# Altium schematic sheet Sheet4.SchDoc(Sheet4)
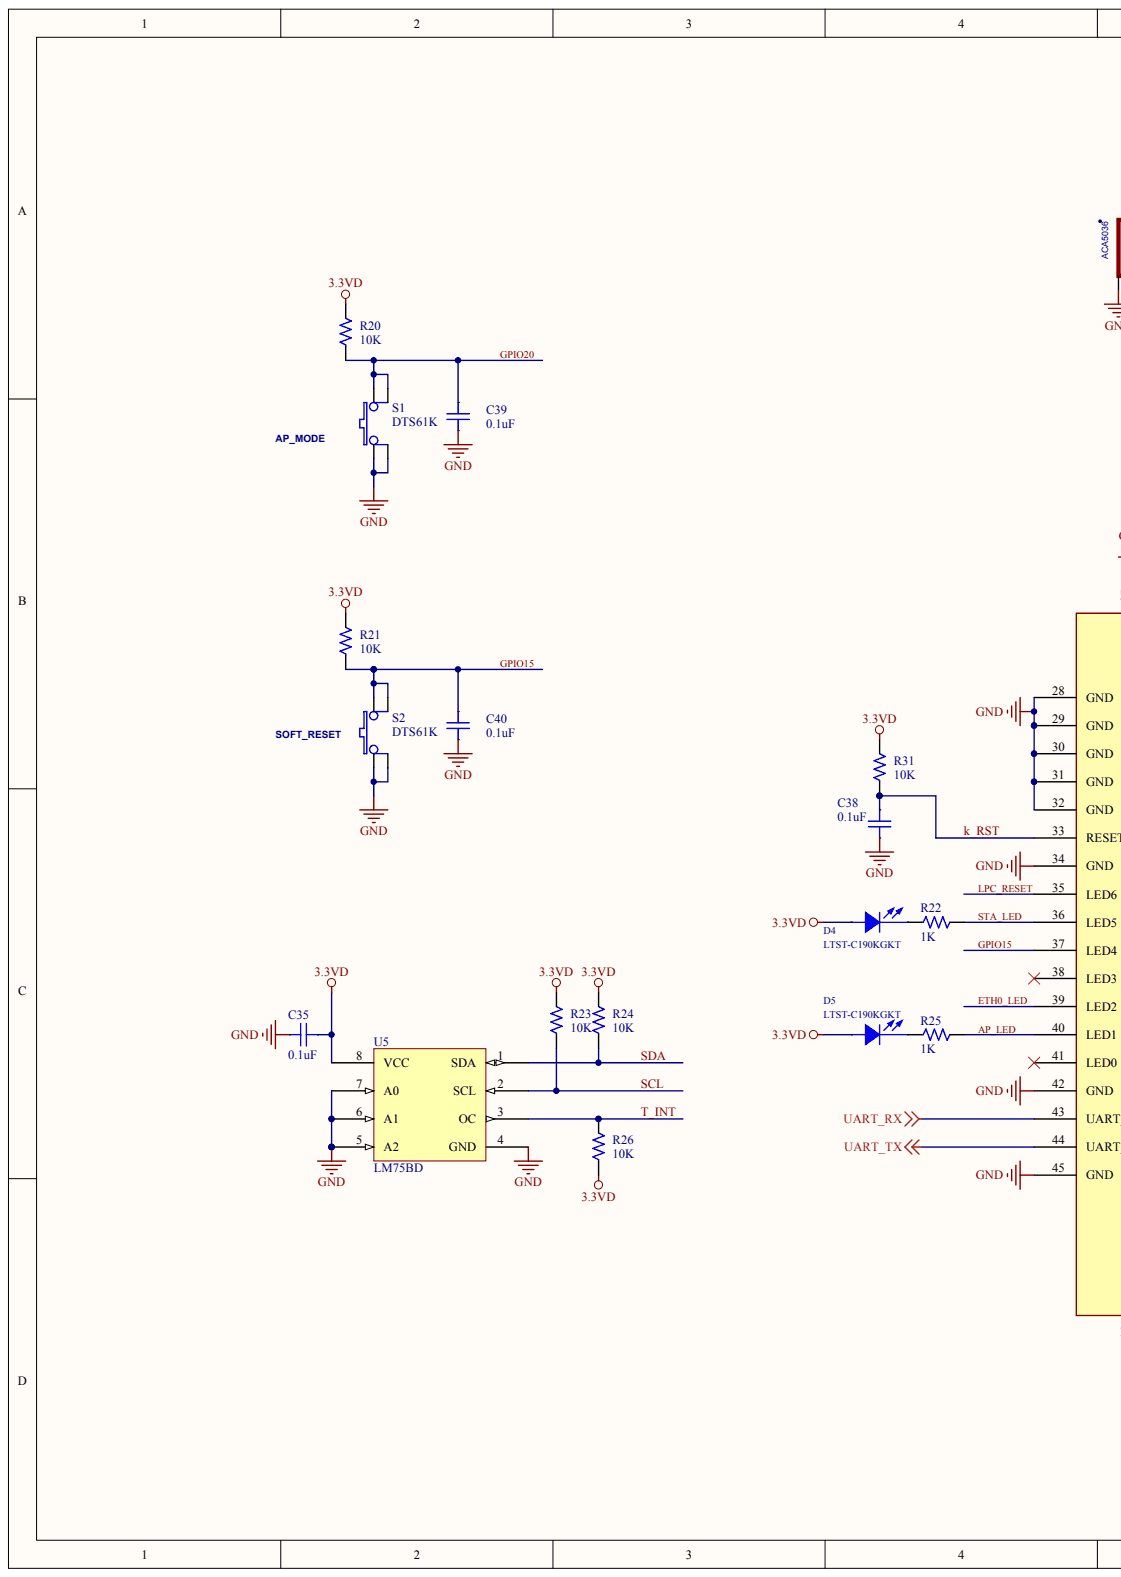
[diagram p0: Sheet4.SchDoc, left]
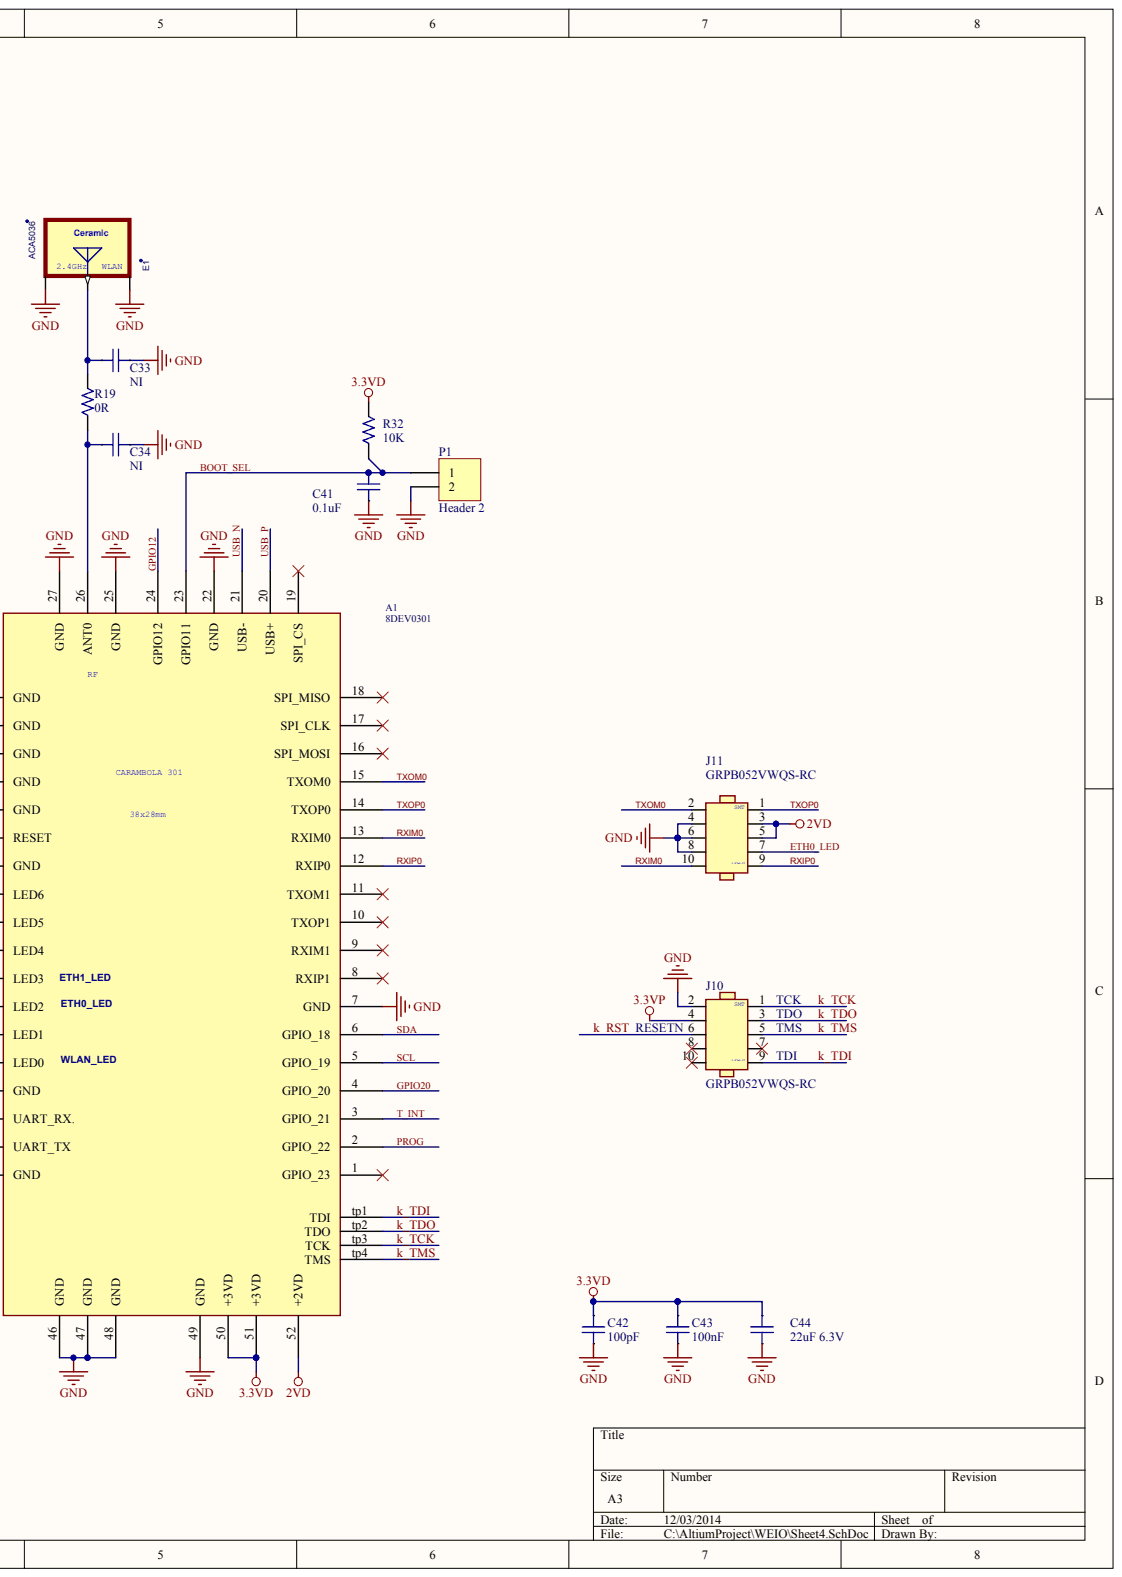
[diagram p1: Sheet4.SchDoc, right]

## Parts
A1  8DEV0301
C33  NI
C34  NI
C35  value 0.1uF  (hidden comment: 100nF)
C38  value 0.1uF  (hidden comment: 100nF)
C39  value 0.1uF  (hidden comment: 100nF)
C40  value 0.1uF  (hidden comment: 100nF)
C41  0.1uF
C42  100pF
C43  100nF
C44  22uF 6.3V
D4  LTST-C190KGKT
D5  LTST-C190KGKT
E1  ACA5036
J10  GRPB052VWQS-RC
J11  GRPB052VWQS-RC
P1  Header 2
R19  0R
R20  10K
R21  10K
R22  1K
R23  10K
R24  10K
R25  1K
R26  10K
R31  10K
R32  10K
S1  DTS61K
S2  DTS61K
U5  LM75BD

## Nets
2VD: A1.52(+2VD) J11.3 J11.5
3.3VD: A1.50(+3VD) A1.51(+3VD) C35.2 C42.2 C43.2 C44.1 D4.1 D5.1 R20.2 R21.2 R23.2 R24.2 R26.1 R31.2 R32.2 U5.8(VCC)
3.3VP: J10.4
GND: A1.7(GND) A1.22(GND) A1.25(GND) A1.27(GND) A1.28(GND) A1.29(GND) A1.30(GND) A1.31(GND) A1.32(GND) A1.34(GND) A1.42(GND) A1.45(GND) A1.46(GND) A1.47(GND) A1.48(GND) A1.49(GND) C33.2 C34.2 C35.1 C38.2 C39.1 C40.1 C41.1 C42.1 C43.1 C44.2 E1.2 E1.3 J10.2 J11.4 J11.6 J11.8 P1.2 S1.1 S1.3 S2.1 S2.3 U5.4(GND) U5.5(A2) U5.6(A1) U5.7(A0)
UART_RX: A1.43(UART_RX.)
UART_TX: A1.44(UART_TX)
AP_LED: A1.40(LED1) R25.2
BOOT_SEL: A1.23(GPIO11) C41.2 P1.1 R32.1
ETH0_LED: A1.39(LED2) J11.7
GPIO12: A1.24(GPIO12)
GPIO15: A1.37(LED4) C40.2 R21.1 S2.2 S2.4
GPIO20: A1.4(GPIO_20) C39.2 R20.1 S1.2 S1.4
K_RST: A1.33(RESET) C38.1 J10.6 R31.1
K_TCK: A1.tp3(TCK) J10.1(SMT)
K_TDI: A1.tp1(TDI) J10.9(1.27x1.27)
K_TDO: A1.tp2(TDO) J10.3
K_TMS: A1.tp4(TMS) J10.5
LPC_RESET: A1.35(LED6)
PROG: A1.2(GPIO_22)
RXIM0: A1.13(RXIM0) J11.10
RXIP0: A1.12(RXIP0) J11.9
SCL: A1.5(GPIO_19) R23.1 U5.2(SCL)
SDA: A1.6(GPIO_18) R24.1 U5.1(SDA)
STA_LED: A1.36(LED5) R22.2
T_INT: A1.3(GPIO_21) R26.2 U5.3(OC)
TXOM0: A1.15(TXOM0) J11.2
TXOP0: A1.14(TXOP0) J11.1(SMT)
USB_N: A1.21(USB-)
USB_P: A1.20(USB+)
NetA1_26: A1.26(ANT0) C34.1 R19.1
NetC33_1: C33.1 E1.1 R19.2
NetD4_2: D4.2 R22.1
NetD5_2: D5.2 R25.1

Not connected: A1 1(GPIO_23) 8(RXIP1) 9(RXIM1) 10(TXOP1) 11(TXOM1) 16(SPI_MOSI) 17(SPI_CLK) 18(SPI_MISO) 19(SPI_CS) 38(LED3) 41(LED0); J10 7 8 10

## Library data of the parts
A1 = (no symbol name): Layout PCB Footprint: 8DEV_0301_0002_28X38_FP
C33 C34 = (no symbol name): Part Number: 00005410; Manufacturer Part Number: C1005XCOG1H3R3C; Manufacturer: TDK; Voltage: 50V; Tolerance: +-5%; PSpice: C; Value: NI; Part Type: Ceramic\1005; RoHS: Yes; Availability: In Stock; Datasheet: E:\pdf\KONDENSATORIAI\CER_smd\e412_c.pdf
C35 C38-C41 C43 = (no symbol name): Part Number: 00005500; Manufacturer Part Number: C1005X5R1C104K; Manufacturer: TDK; Voltage: 16V; Tolerance: +-10%; PSpice: C; Part Type: Ceramic\1005; RoHS: Yes; Availability: In Stock; Datasheet: E:\pdf\KONDENSATORIAI\CER_smd\e412_c.pdf
  C41: Value: 0.1uF
  C43: Value: 100nF
C42 = (no symbol name): Part Number: 00005450; Manufacturer Part Number: C1005XCOG1H101JT; Manufacturer: TDK; Voltage: 50V; Tolerance: +-5%; PSpice: C; Value: 100pF; Part Type: Ceramic\1005; RoHS: Yes; Availability: In Stock; Datasheet: E:\pdf\KONDENSATORIAI\CER_smd\e412_c.pdf
C44 = (no symbol name): Part Number: 00000312; Manufacturer Part Number: GRM21BR60J226ME39L; Manufacturer: MURATA; Tolerance: +-20%; PSpice: C; Gaminys: TM2; Value: 22uF 6.3V; Part Type: Ceramic\2012; RoHS: Yes; Voltage: 6.3V; Distributor Part Number: 81-GRM21R60J226ME39L; Distributor: MOUSER; Price: 0.816; Package: 180mm Emb T&R3000; Datasheet: E:\pdf\KONDENSATORIAI\CER_SMD\c02e.pdf
D4 = (no symbol name): Manufacturer: LITEON; Part Number: 32000102; Rating: 100mW; PSpice: D; SMD: Yes; Manufacturer Part Number: LTST-C190KGKT; Distributor Part Number: 160-1183-2-ND; Distributor: Digi-Key; Price: 0.113; Part Type: Diode\LED SMD; Package: 3000T&R; Datasheet: E:\pdf\diodai\led\ltst-c190ckt.pdf
D5 = (no symbol name): Part_Number: 32000102; Manufacturer: LITEON; Layout PCB Footprint: LED0603; Komentaras: $; COMPHEIGHT: 0.8; SMD: Yes; Manufacturer Part Number: LTST-C190KGKT; Distributor Part Number: 160-1183-2-ND; Distributor: Digi-Key; Price: 0.06
E1 = (no symbol name): Manufacturer: INPAQ TECHNOLOGY; Manufacturer Part Number: ACA-5036-A2-CC-S; Part_Number: 95200221W; COMPHEIGHT: 0.7; Layout PCB Footprint: ACA5036; SMD: Yes
J10 J11 = (no symbol name): Part Number: 70200208W; Manufacturer: Sullins Connector Solutions; COMPHEIGHT: 5; Rating: 250V; Allegro PCB Footprint: 127X127_12MH_SMT_H5; Gaminys: 8DEV; Komentaras: $; Part Type: Connector\1.27x1.27; SMD: Yes; Manufacturer Part Number: GRPB052VWQS-RC; Distributor Part Number: S9012E-05-ND; Distributor: Digi-Key; Price: 0.5075; Package: tube; ActivepartsID: http://media.digikey.com/pdf/Data%20Sheets/Sullins%20PDFs/GRPB_%20_2VWQS-RC%2010958-C.pdf; Data: 2013-06-07; Datasheet: E:\PDF\Jungtys\GRPB_ _2VWQS-RC 10958-C.pdf
R19 = (no symbol name): Part Number: 10011000; Manufacturer Part Number: RC0402JR-070RL; Manufacturer: YAGEO; COMPHEIGHT: 0.35; Power: 1/16W; Tolerance: 5%; Allegro PCB Footprint: R1005_TM2,R0402; PSpice: R; Lead time: Stock; Komentaras: $; Value: 0R; Part type: SMD\0402; SMD: Yes; Distributor Part Number: 311-0.0JRTR-ND; Distributor: Digi-Key; Price: 0.0013; Package: 10000T&R; Availability: In Stock; Main distributor: Rutronik; MOQ: 10000; ActivepartsID: http://www.yageo.com/pdf/Pu-RC0402_51_PbFree_L_2.pdf; Data: 07/11/2011; Datasheet: E:\pdf\rezistoriai\PSG_R-chip_Yageo_2005.pdf
R20 R21 R23 R24 R26 R31 R32 = (no symbol name): Part Number: 10011104; Manufacturer Part Number: RC0402JR-10KL; Manufacturer: YAGEO; COMPHEIGHT: 0.35; Power: 1/16W; Tolerance: 5%; Allegro PCB Footprint: R1005_TM2,R0402; PSpice: R; Komentaras: $; Value: 10K; Part type: SMD\0402; SMD: Yes; Distributor Part Number: 311-10KJRTR-ND; Distributor: Digi-Key; Price: 0.00213; Package: 10000T&R; Availability: In Stock; ActivepartsID: http://www.yageo.com/pdf/Pu-RC0402_51_PbFree_L_2.pdf; Data: 27/08/2010; Datasheet: E:\pdf\rezistoriai\PSG_R-chip_Yageo_2005.pdf
R22 R25 = (no symbol name): Part Number: 10011080; Manufacturer Part Number: RC0402JR-1KL; Manufacturer: YAGEO; COMPHEIGHT: 0.35; Power: 1/16W; Tolerance: 5%; Allegro PCB Footprint: R1005_TM2; PSpice: R; Value: 1K; Part type: SMD\0402; SMD: Yes; Availability: In Stock; Datasheet: E:\pdf\rezistoriai\PSG_R-chip_Yageo_2005.pdf
S1 S2 = (no symbol name): Part Number: 88000001; Manufacturer: Diptronics; Value: DTS61K; Part Type: Switch\Tact; RoHS: Yes; Manufacturer Part Number: DTS61K; Distributor Part Number: E3341 DTS61K; Distributor: SCHUKAT; Price: 0.1885
P1 U5 = (no symbol name)
  P1: LatestRevisionDate: 17-Jul-2002; LatestRevisionNote: Re-released for DXP Platform.; Publisher: Altium Limited
  U5: 222: *
